# Altium schematic sheet CPU.SchDoc(CPU)
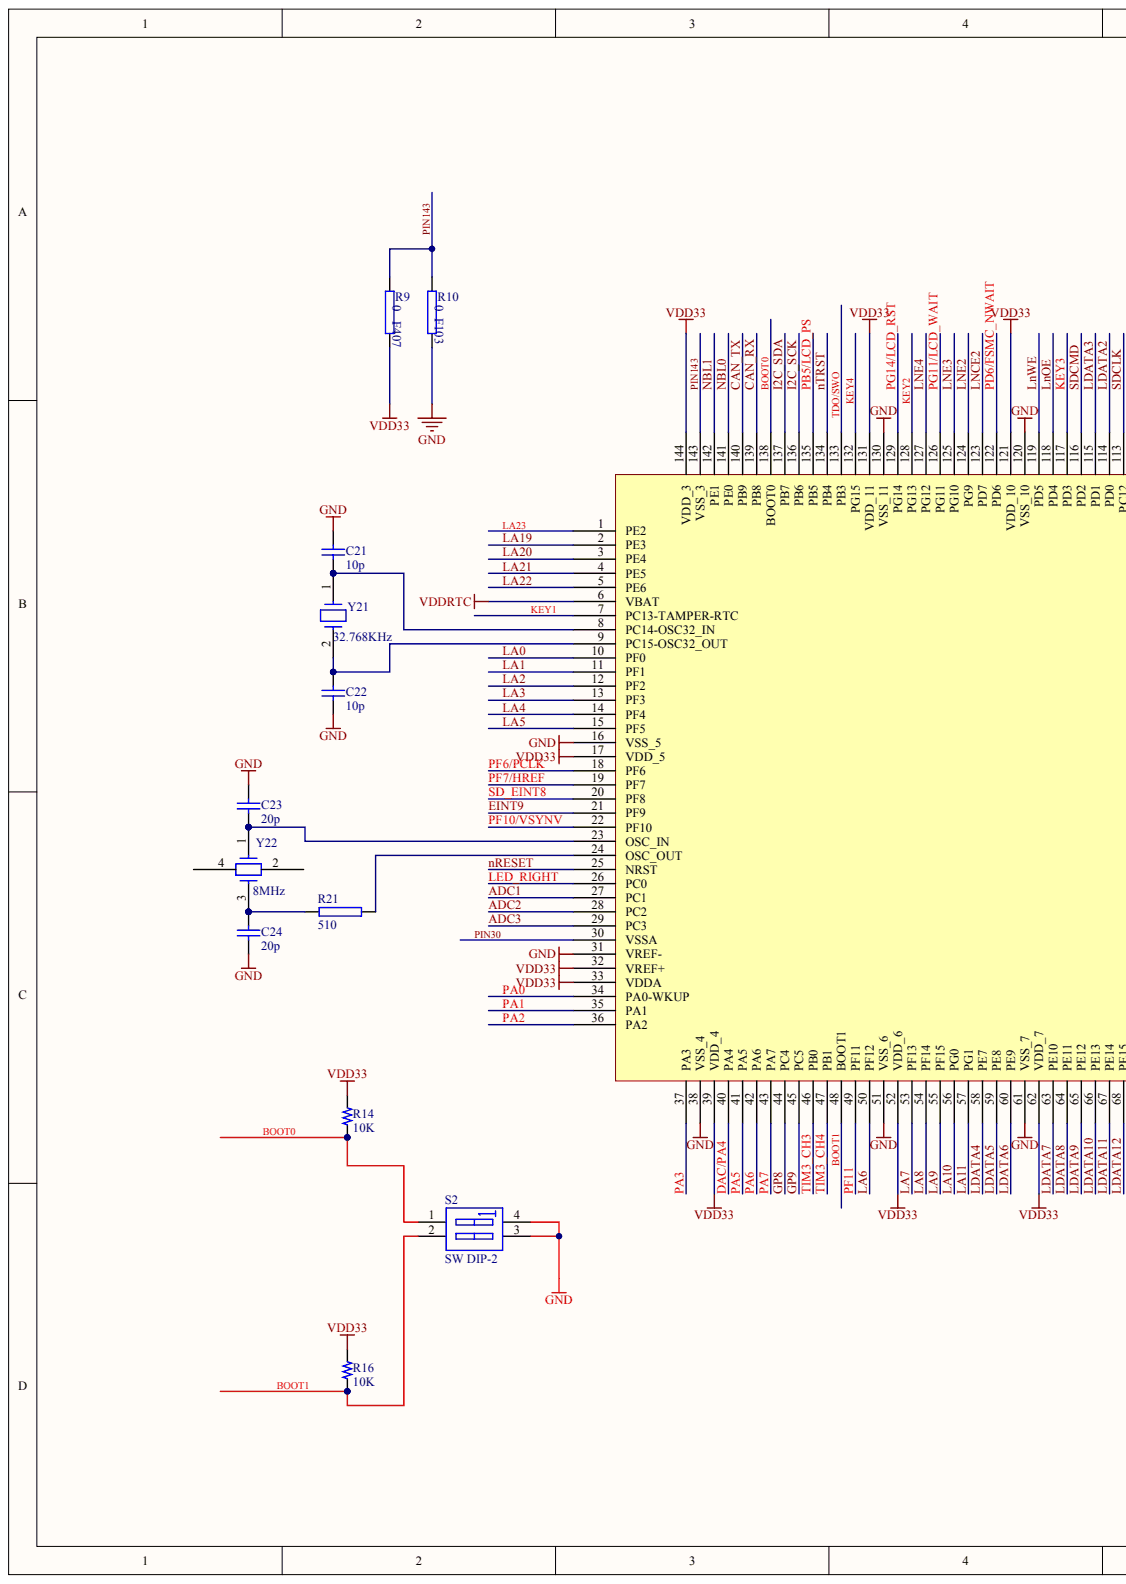
[diagram p0: CPU.SchDoc, left]
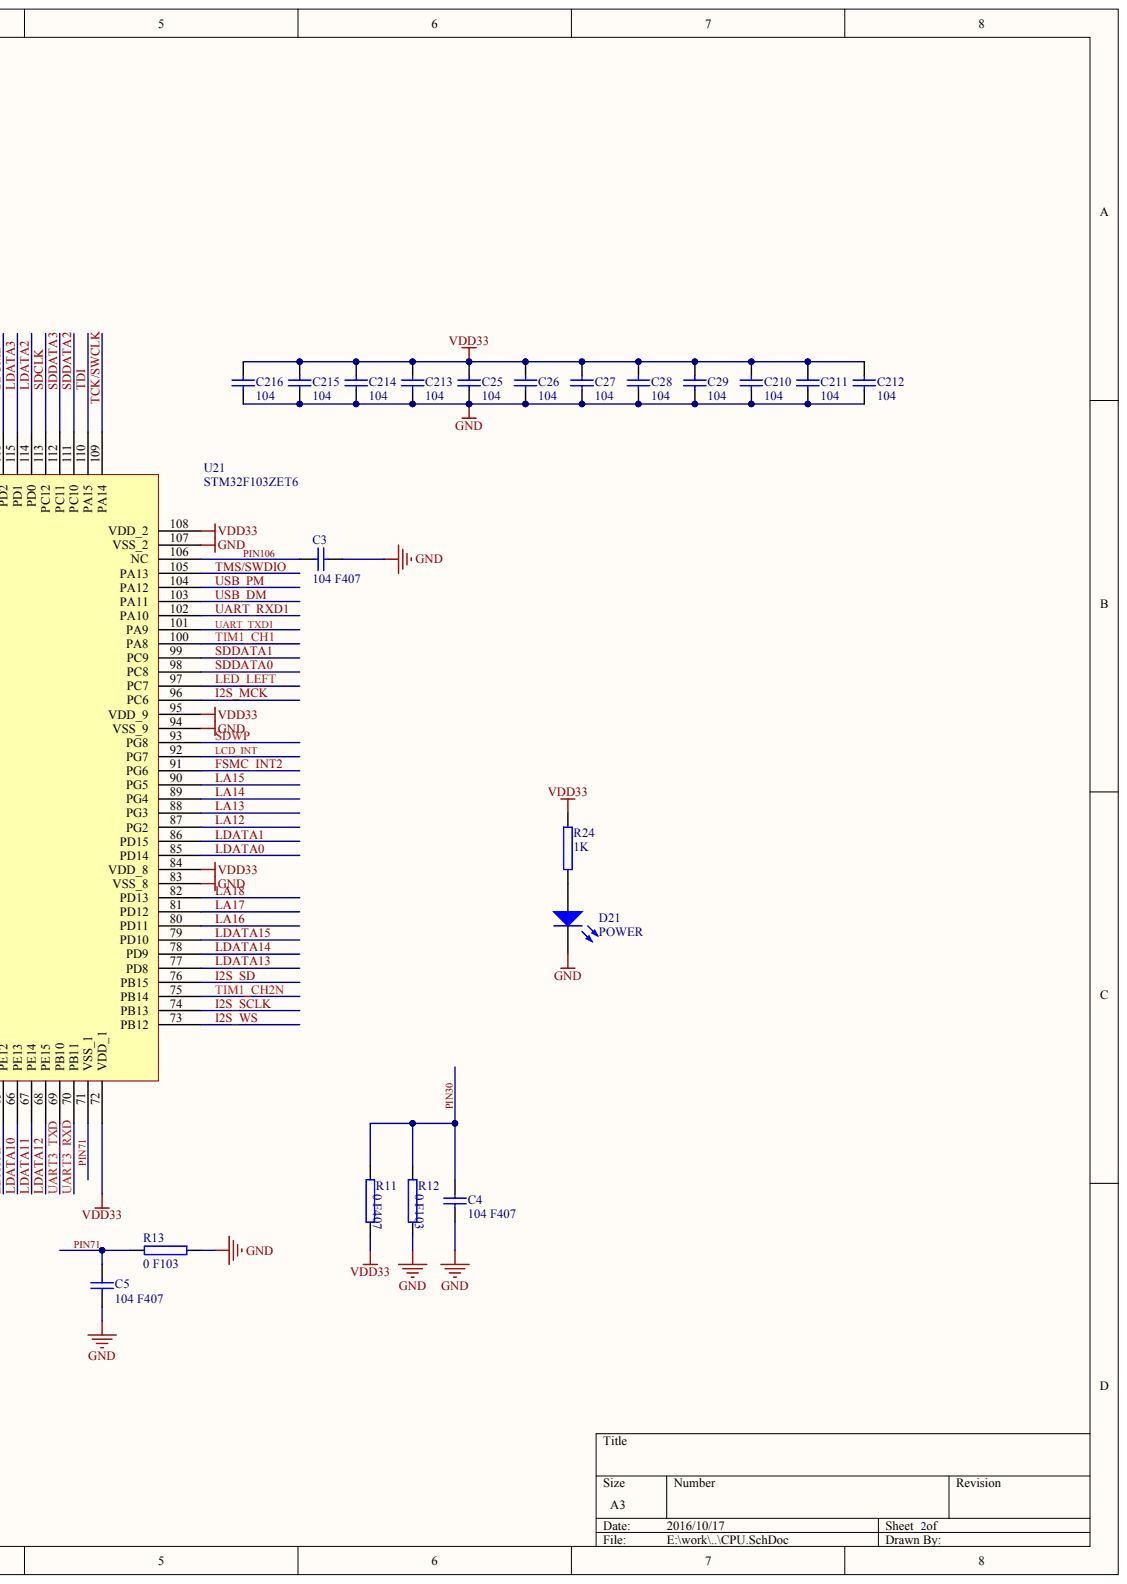
[diagram p1: CPU.SchDoc, right]

## Parts
C3  value 104 F407  (hidden comment: 10P)
C4  value 104 F407  (hidden comment: 10P)
C5  value 104 F407  (hidden comment: 10P)
C21  10P
C22  10P
C23  20p
C24  20p
C25  104
C26  104
C27  104
C28  104
C29  104
C210  104
C211  104
C212  104
C213  104
C214  104
C215  104
C216  104
D21  POWER
R9  0 F407  value 0   F407
R10  0 F103  value 0  F103
R11  0 F407
R12  0 F103
R13  0 F103
R14  10K
R16  10K
R21  510
R24  1K
S2  SW DIP-2
U21  STM32F103ZET6
Y21  32.768KHz
Y22  8MHz

## Nets
GND: C3.2 C4.1 C5.1 C21.2 C22.1 C23.2 C24.1 C25.1 C26.1 C27.1 C28.1 C29.1 C210.1 C211.1 C212.1 C213.1 C214.1 C215.1 C216.1 D21.2 R10.1 R12.1 R13.1 S2.3 S2.4 U21.16(VSS_5) U21.31(VREF-) U21.38(VSS_4) U21.51(VSS_6) U21.61(VSS_7) U21.83(VSS_8) U21.94(VSS_9) U21.107(VSS_2) U21.120(VSS_10) U21.130(VSS_11)
VDD33: C25.2 C26.2 C27.2 C28.2 C29.2 C210.2 C211.2 C212.2 C213.2 C214.2 C215.2 C216.2 R9.1 R11.1 R14.1 R16.1 R24.1 U21.17(VDD_5) U21.32(VREF+) U21.33(VDDA) U21.39(VDD_4) U21.52(VDD_6) U21.62(VDD_7) U21.72(VDD_1) U21.84(VDD_8) U21.95(VDD_9) U21.108(VDD_2) U21.121(VDD_10) U21.131(VDD_11) U21.144(VDD_3)
VDDRTC: U21.6(VBAT)
ADC1: U21.27(PC1)
ADC2: U21.28(PC2)
ADC3: U21.29(PC3)
BOOT0: R14.2 S2.1 U21.138(BOOT0)
BOOT1: R16.2 S2.2 U21.48(BOOT1)
CAN_RX: U21.139(PB8)
CAN_TX: U21.140(PB9)
DAC/PA4: U21.40(PA4)
EINT9: U21.21(PF9)
FSMC_INT2: U21.91(PG6)
GP8: U21.44(PC4)
GP9: U21.45(PC5)
I2C_SCK: U21.136(PB6)
I2C_SDA: U21.137(PB7)
I2S_MCK: U21.96(PC6)
I2S_SCLK: U21.74(PB13)
I2S_SD: U21.76(PB15)
I2S_WS: U21.73(PB12)
KEY1: U21.7(PC13-TAMPER-RTC)
KEY2: U21.128(PG13)
KEY3: U21.117(PD3)
KEY4: U21.132(PG15)
LA0: U21.10(PF0)
LA1: U21.11(PF1)
LA2: U21.12(PF2)
LA3: U21.13(PF3)
LA4: U21.14(PF4)
LA5: U21.15(PF5)
LA6: U21.50(PF12)
LA7: U21.53(PF13)
LA8: U21.54(PF14)
LA9: U21.55(PF15)
LA10: U21.56(PG0)
LA11: U21.57(PG1)
LA12: U21.87(PG2)
LA13: U21.88(PG3)
LA14: U21.89(PG4)
LA15: U21.90(PG5)
LA16: U21.80(PD11)
LA17: U21.81(PD12)
LA18: U21.82(PD13)
LA19: U21.2(PE3)
LA20: U21.3(PE4)
LA21: U21.4(PE5)
LA22: U21.5(PE6)
LA23: U21.1(PE2)
LCD_INT: U21.92(PG7)
LDATA0: U21.85(PD14)
LDATA1: U21.86(PD15)
LDATA2: U21.114(PD0)
LDATA3: U21.115(PD1)
LDATA4: U21.58(PE7)
LDATA5: U21.59(PE8)
LDATA6: U21.60(PE9)
LDATA7: U21.63(PE10)
LDATA8: U21.64(PE11)
LDATA9: U21.65(PE12)
LDATA10: U21.66(PE13)
LDATA11: U21.67(PE14)
LDATA12: U21.68(PE15)
LDATA13: U21.77(PD8)
LDATA14: U21.78(PD9)
LDATA15: U21.79(PD10)
LED_LEFT: U21.97(PC7)
LED_RIGHT: U21.26(PC0)
LNCE2: U21.123(PD7)
LNE2: U21.124(PG9)
LNE3: U21.125(PG10)
LNE4: U21.127(PG12)
LNOE: U21.118(PD4)
LNWE: U21.119(PD5)
NBL0: U21.141(PE0)
NBL1: U21.142(PE1)
NRESET: U21.25(NRST)
NTRST: U21.134(PB4)
PA0: U21.34(PA0-WKUP)
PA1: U21.35(PA1)
PA2: U21.36(PA2)
PA3: U21.37(PA3)
PA5: U21.41(PA5)
PA6: U21.42(PA6)
PA7: U21.43(PA7)
PB5/LCD_PS: U21.135(PB5)
PD6/FSMC_NWAIT: U21.122(PD6)
PF6/PCLK: U21.18(PF6)
PF7/HREF: U21.19(PF7)
PF10/VSYNV: U21.22(PF10)
PF11: U21.49(PF11)
PG11/LCD_WAIT: U21.126(PG11)
PG14/LCD_RST: U21.129(PG14)
PIN30: C4.2 R11.2 R12.2 U21.30(VSSA)
PIN71: C5.2 R13.2 U21.71(VSS_1)
PIN106: C3.1 U21.106(NC)
PIN143: R9.2 R10.2 U21.143(VSS_3)
SD_EINT8: U21.20(PF8)
SDCLK: U21.113(PC12)
SDCMD: U21.116(PD2)
SDDATA0: U21.98(PC8)
SDDATA1: U21.99(PC9)
SDDATA2: U21.111(PC10)
SDDATA3: U21.112(PC11)
SDWP: U21.93(PG8)
TCK/SWCLK: U21.109(PA14)
TDI: U21.110(PA15)
TDO/SWO: U21.133(PB3)
TIM1_CH1: U21.100(PA8)
TIM1_CH2N: U21.75(PB14)
TIM3_CH3: U21.46(PB0)
TIM3_CH4: U21.47(PB1)
TMS/SWDIO: U21.105(PA13)
UART3_RXD: U21.70(PB11)
UART3_TXD: U21.69(PB10)
UART_RXD1: U21.102(PA10)
UART_TXD1: U21.101(PA9)
USB_DM: U21.103(PA11)
USB_PM: U21.104(PA12)
NetC21_1: C21.1 U21.8(PC14-OSC32_IN) Y21.1
NetC22_2: C22.2 U21.9(PC15-OSC32_OUT) Y21.2
NetC23_1: C23.1 U21.23(OSC_IN) Y22.1
NetC24_2: C24.2 R21.1 Y22.3
NetD21_1: D21.1 R24.2
NetR21_2: R21.2 U21.24(OSC_OUT)

Not connected: Y22 2 4

## Library data of the parts
C3-C5 C21-C29 C210-C216 D21 R9-R14 R16 R21 R24 S2 U21 Y21 Y22 = (no symbol name)
  C3-C5 D21: Published: 8-Jun-2000; LatestRevisionDate: 17-Jul-2002; LatestRevisionNote: Re-released for DXP Platform.
  C21 C22: Published: 8-Jun-2000; LatestRevisionDate: 17-Jul-2002; LatestRevisionNote: Re-released for DXP Platform.; Value: 10p
  C23 C24: Published: 8-Jun-2000; LatestRevisionDate: 17-Jul-2002; LatestRevisionNote: Re-released for DXP Platform.; Value: 20p
  C25-C29 C210-C216: Published: 8-Jun-2000; LatestRevisionDate: 17-Jul-2002; LatestRevisionNote: Re-released for DXP Platform.; Value: 104
  R11: Value: 0 F407
  R12 R13: Value: 0 F103
  R21: Value: 510
  R24: Value: 1K
  S2: Published: 24-Mar-1999; LatestRevisionDate: 17-Jul-2002; LatestRevisionNote: Re-released for DXP Platform.; PackageReference: DIP-4
  C3-C5 C21-C29 C210-C216 D21 S2: Publisher: Altium Limited
